# Altium schematic sheet Power.SchDoc(Power)
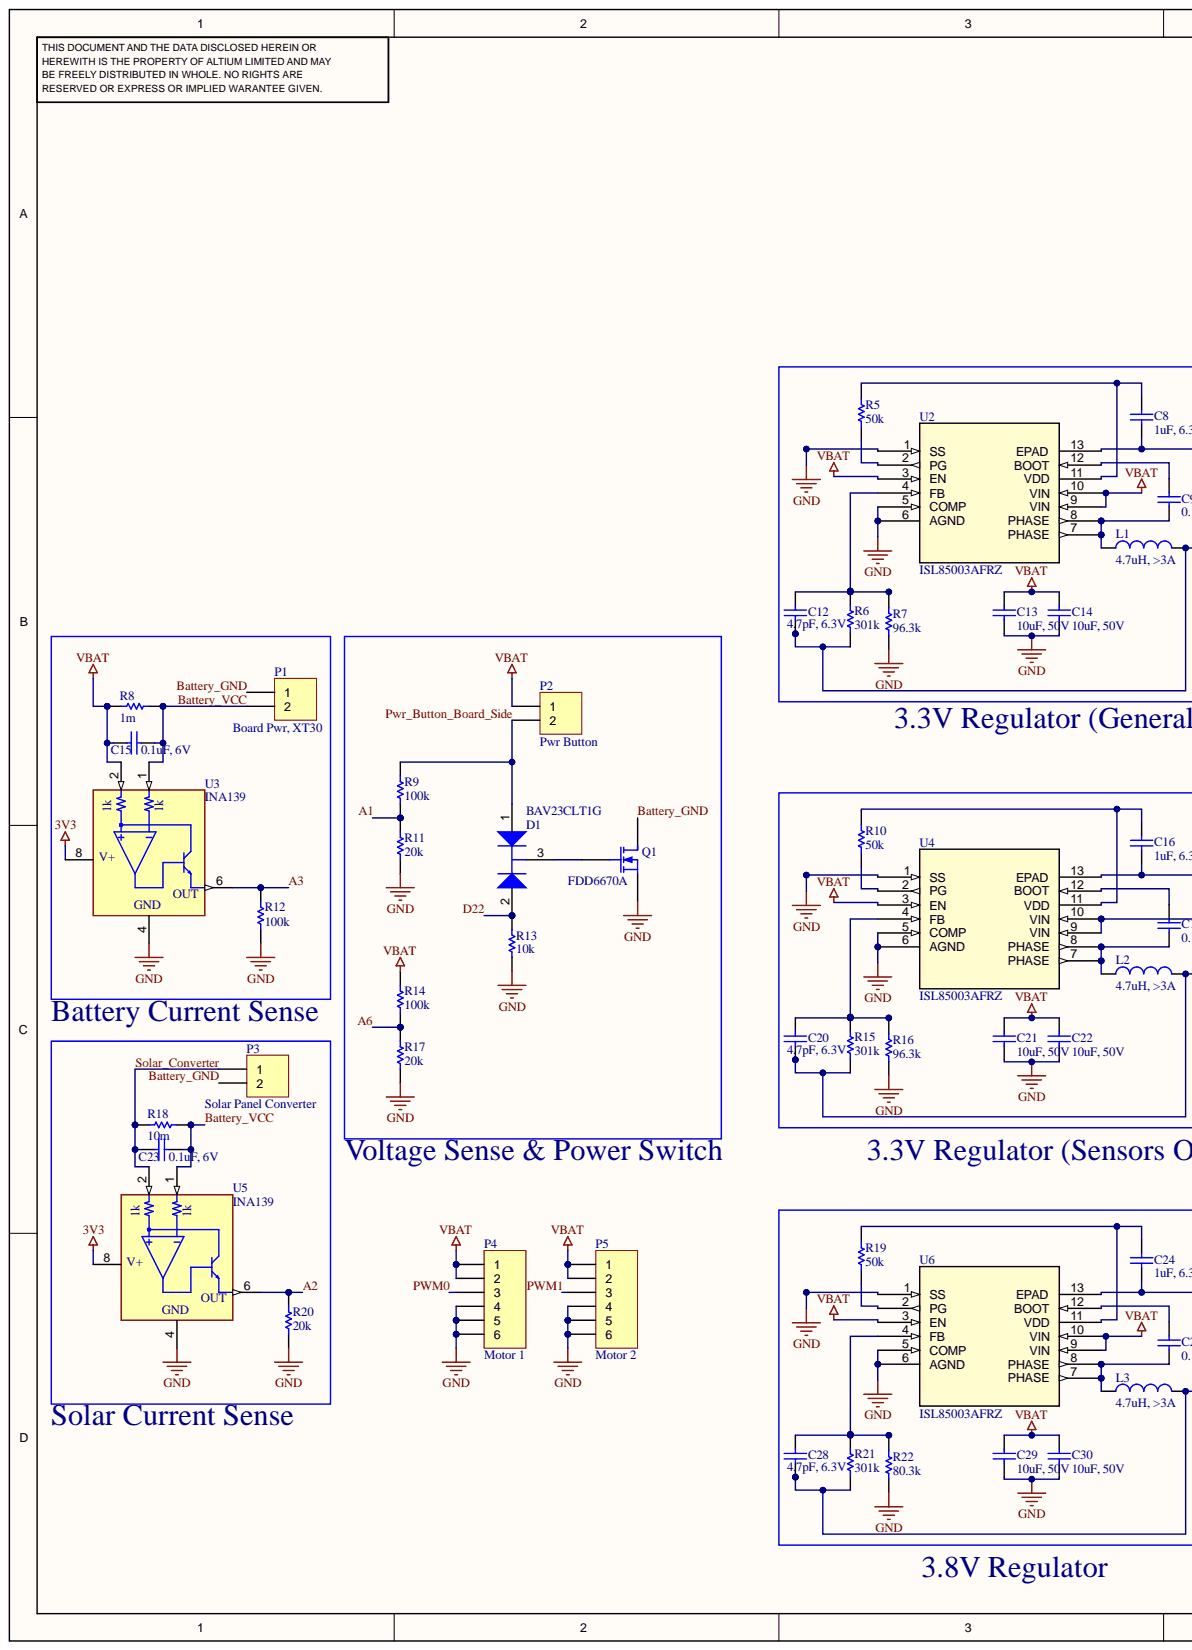
[diagram p0: Power.SchDoc, left]
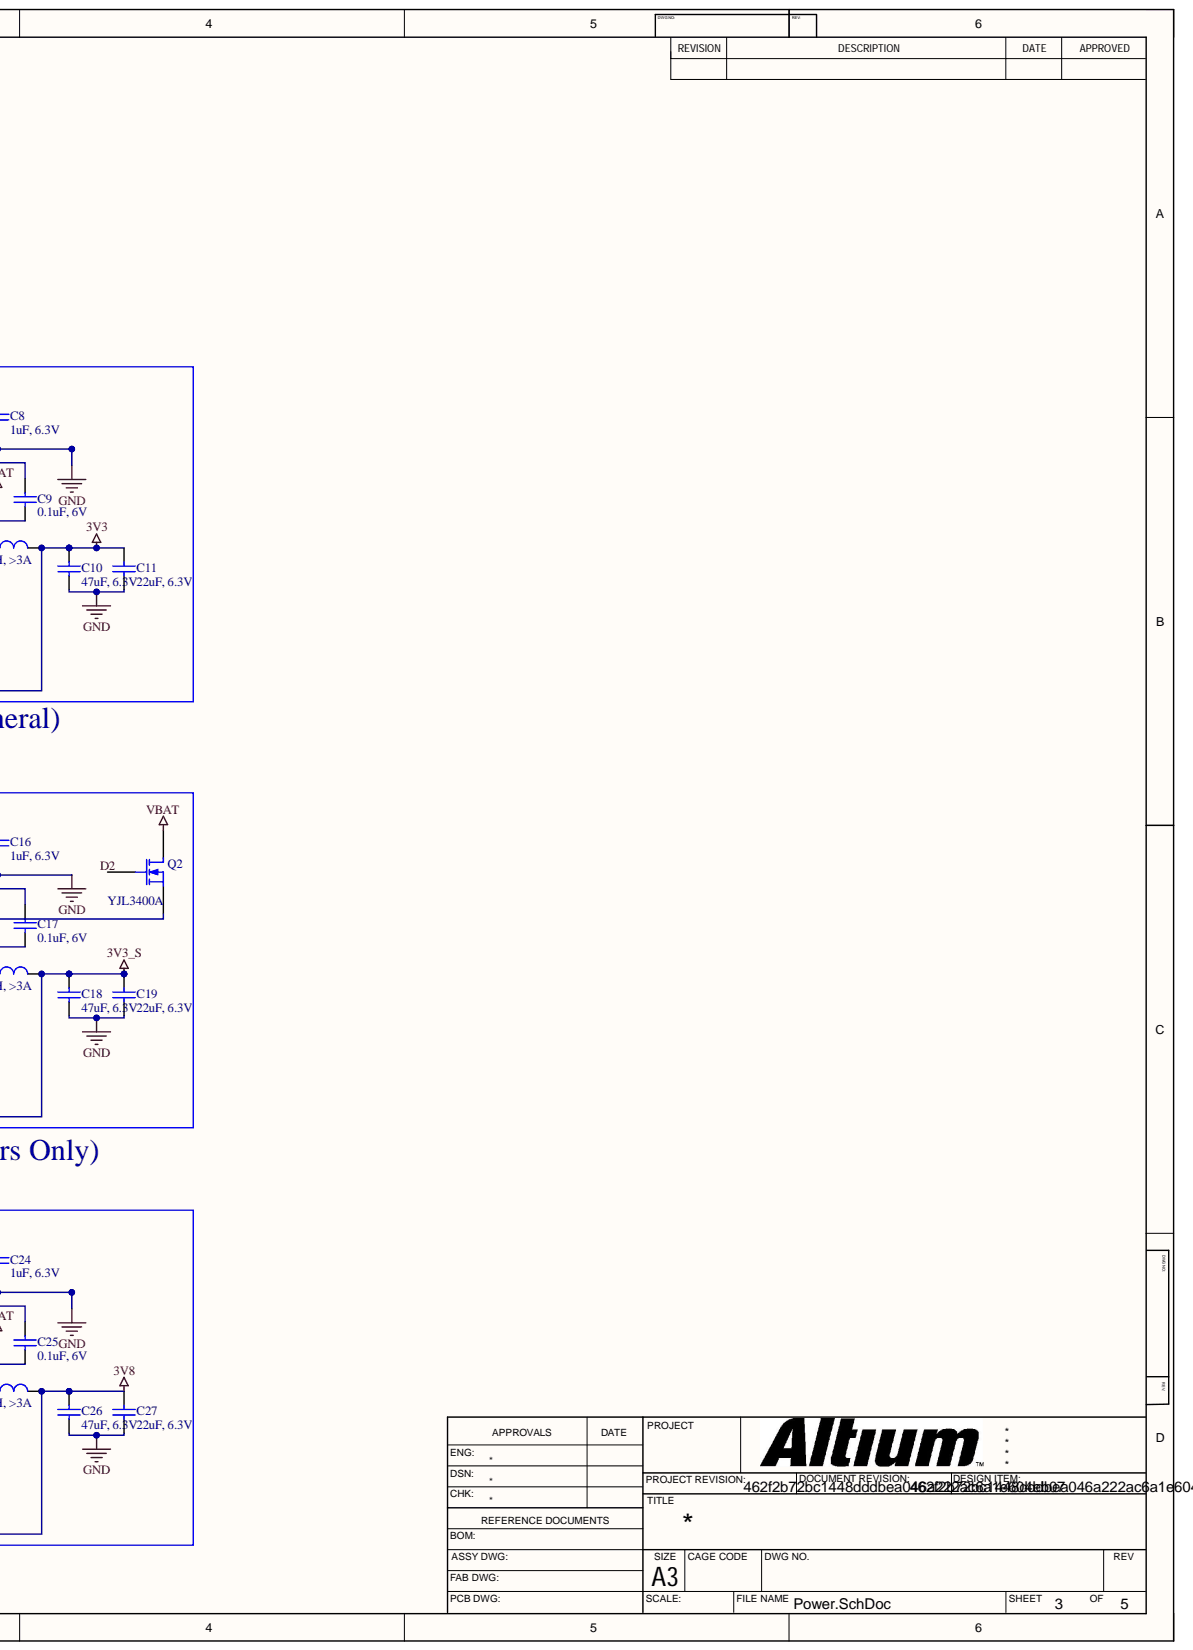
[diagram p1: Power.SchDoc, right]

## Parts
C8  value 1uF, 6.3V  [ANTC1608X90N]  (hidden comment: Capacitor)
C9  value 0.1uF, 6V  [ANTC1608X90N]  (hidden comment: Capacitor)
C10  value 47uF, 6.3V  [ANTC1608X90N]  (hidden comment: Capacitor)
C11  value 22uF, 6.3V  [ANTC1608X90N]  (hidden comment: Capacitor)
C12  value 4.7pF, 6.3V  [ANTC1608X90N]  (hidden comment: Capacitor)
C13  value 10uF, 50V  [CAPC2012X145N]  (hidden comment: Capacitor)
C14  value 10uF, 50V  [CAPC2012X145N]  (hidden comment: Capacitor)
C15  value 0.1uF, 6V  [ANTC1608X90N]  (hidden comment: Capacitor)
C16  value 1uF, 6.3V  [ANTC1608X90N]  (hidden comment: Capacitor)
C17  value 0.1uF, 6V  [ANTC1608X90N]  (hidden comment: Capacitor)
C18  value 47uF, 6.3V  [ANTC1608X90N]  (hidden comment: Capacitor)
C19  value 22uF, 6.3V  [ANTC1608X90N]  (hidden comment: Capacitor)
C20  value 4.7pF, 6.3V  [ANTC1608X90N]  (hidden comment: Capacitor)
C21  value 10uF, 50V  [CAPC2012X145N]  (hidden comment: Capacitor)
C22  value 10uF, 50V  [CAPC2012X145N]  (hidden comment: Capacitor)
C23  value 0.1uF, 6V  [ANTC1608X90N]  (hidden comment: Capacitor)
C24  value 1uF, 6.3V  [ANTC1608X90N]  (hidden comment: Capacitor)
C25  value 0.1uF, 6V  [ANTC1608X90N]  (hidden comment: Capacitor)
C26  value 47uF, 6.3V  [ANTC1608X90N]  (hidden comment: Capacitor)
C27  value 22uF, 6.3V  [ANTC1608X90N]  (hidden comment: Capacitor)
C28  value 4.7pF, 6.3V  [ANTC1608X90N]  (hidden comment: Capacitor)
C29  value 10uF, 50V  [CAPC2012X145N]  (hidden comment: Capacitor)
C30  value 10uF, 50V  [CAPC2012X145N]  (hidden comment: Capacitor)
D1  BAV23CLT1G  [ONSC-SOT-23-3-318-08_V]
L1  value 4.7uH, >3A  [IND_NR6028T4R7M]  (hidden comment: Inductor)
L2  value 4.7uH, >3A  [IND_NR6028T4R7M]  (hidden comment: Inductor)
L3  value 4.7uH, >3A  [IND_NR6028T4R7M]  (hidden comment: Inductor)
P1  Board Pwr, XT30  [XT30_Horizontal]
P2  Pwr Button  [TE_6-440054-2]
P3  Solar Panel Converter  [XT30_Horizontal]
P4  Motor 1  [TE_1586039-6]
P5  Motor 2  [TE_1586039-6]
Q1  FDD6670A  [DPAK]
Q2  YJL3400A  [SOT23-3]
R5  value 50k  [ANTC1608X90N]  (hidden comment: Resistor)
R6  value 301k  [ANTC1608X90N]  (hidden comment: Resistor)
R7  value 96.3k  [ANTC1608X90N]  (hidden comment: Resistor)
R8  value 1m  [RESC6331X70N]  (hidden comment: Resistor)
R9  value 100k  [ANTC1608X90N]  (hidden comment: Resistor)
R10  value 50k  [ANTC1608X90N]  (hidden comment: Resistor)
R11  value 20k  [ANTC1608X90N]  (hidden comment: Resistor)
R12  value 100k  [ANTC1608X90N]  (hidden comment: Resistor)
R13  value 10k  [ANTC1608X90N]  (hidden comment: Resistor)
R14  value 100k  [ANTC1608X90N]  (hidden comment: Resistor)
R15  value 301k  [ANTC1608X90N]  (hidden comment: Resistor)
R16  value 96.3k  [ANTC1608X90N]  (hidden comment: Resistor)
R17  value 20k  [ANTC1608X90N]  (hidden comment: Resistor)
R18  value 10m  [RESC6331X70N]  (hidden comment: Resistor)
R19  value 50k  [ANTC1608X90N]  (hidden comment: Resistor)
R20  value 20k  [ANTC1608X90N]  (hidden comment: Resistor)
R21  value 301k  [ANTC1608X90N]  (hidden comment: Resistor)
R22  value 80.3k  [ANTC1608X90N]  (hidden comment: Resistor)
U2  ISL85003AFRZ  [SON50P300X300X100-13N(270X200)]
U3  INA139  [INA139-Q1]
U4  ISL85003AFRZ  [SON50P300X300X100-13N(270X200)]
U5  INA139  [INA139-Q1]
U6  ISL85003AFRZ  [SON50P300X300X100-13N(270X200)]

## Nets
3V3: C10.2 C11.2 C12.1 L1.2 R6.1 U3.8(V+) U5.8(V+)
3V3_S: C18.2 C19.2 C20.1 L2.2 R15.1
3V8: C26.2 C27.2 C28.1 L3.2 R21.1
GND: C8.1 C10.1 C11.1 C13.1 C14.1 C16.1 C18.1 C19.1 C21.1 C22.1 C24.1 C26.1 C27.1 C29.1 C30.1 P4.4 P4.5 P4.6 P5.4 P5.5 P5.6 Q1.3 R7.1 R11.1 R12.1 R13.1 R16.1 R17.1 R20.1 R22.1 U2.1(SS) U2.5(COMP) U2.6(AGND) U2.13(EPAD) U3.4 U4.1(SS) U4.5(COMP) U4.6(AGND) U4.13(EPAD) U5.4 U6.1(SS) U6.5(COMP) U6.6(AGND) U6.13(EPAD)
VBAT: C13.2 C14.2 C15.2 C21.2 C22.2 C29.2 C30.2 P2.1 P4.1 P4.2 P5.1 P5.2 Q2.1 R8.2 R14.2 U2.3(EN) U2.9(VIN) U2.10(VIN) U3.2 U4.3(EN) U6.3(EN) U6.9(VIN) U6.10(VIN)
A1: R9.1 R11.2
A2: R20.2 U5.6
A3: R12.2 U3.6
A6: R14.1 R17.2
Battery_GND: P1.1 P3.2 Q1.1
Battery_VCC: C15.1 C23.1 P1.2 R8.1 R18.2 U3.1 U5.1
D2: Q2.2
D22: D1.2 R13.2
PWM0: P4.3
PWM1: P5.3
Pwr_Button_Board_Side: D1.1 P2.2 R9.2
Solar_Converter: C23.2 P3.1 R18.1 U5.2
NetC8_2: C8.2 R5.2 U2.11(VDD)
NetC9_1: C9.1 L1.1 U2.7(PHASE) U2.8(PHASE)
NetC9_2: C9.2 U2.12(BOOT)
NetC12_2: C12.2 R6.2 R7.2 U2.4(FB)
NetC16_2: C16.2 R10.2 U4.11(VDD)
NetC17_1: C17.1 L2.1 U4.7(PHASE) U4.8(PHASE)
NetC17_2: C17.2 U4.12(BOOT)
NetC20_2: C20.2 R15.2 R16.2 U4.4(FB)
NetC24_2: C24.2 R19.2 U6.11(VDD)
NetC25_1: C25.1 L3.1 U6.7(PHASE) U6.8(PHASE)
NetC25_2: C25.2 U6.12(BOOT)
NetC28_2: C28.2 R21.2 R22.2 U6.4(FB)
NetD1_3: D1.3 Q1.2
NetQ2_3: Q2.3 U4.9(VIN) U4.10(VIN)
NetR5_1: R5.1 U2.2(PG)
NetR10_1: R10.1 U4.2(PG)
NetR19_1: R19.1 U6.2(PG)

## Library data of the parts
C8 C9 C11 C12 C14 C16 C17 C19 C20 C22 C24 C25 C27 C28 C30 = Capacitor: SimulationGenericComponent: True; Component Kind: Standard; Description: Capacitor; Library Name: Simulation Generic Components.IntLib; Simulation: C_Capacitor_Default
C10 C18 C26 = Capacitor: SimulationGenericComponent: True; Value: 47uF, 6.3V; Component Kind: Standard; Description: Capacitor; Library Name: Simulation Generic Components.IntLib; Simulation: C_Capacitor_Default
C13 C21 C29 = Capacitor: SimulationGenericComponent: True; Value: 10uF, 50V; Component Kind: Standard; Description: Capacitor; Library Name: Simulation Generic Components.IntLib; Simulation: C_Capacitor_Default
C15 C23 = Capacitor: SimulationGenericComponent: True; Value: 0.1uF, 6V; Component Kind: Standard; Description: Capacitor; Library Name: Simulation Generic Components.IntLib; Simulation: C_Capacitor_Default
D1 = CMP-1054-00102-1: Dual High Voltage Common Cathode Switching Diodes, 3-Pin SOT-23, Pb-Free, Tape and Reel; Manufacturer: On Semiconductor; IF Max (A): 0.4; trr Max (ns): 150; Component Kind: Standard; ComponentLink1Description: Manufacturer URL; ComponentLink1URL: http://www.onsemi.com/; ComponentLink2Description: Datasheet; ComponentLink2URL: http://www.onsemi.com/pub_link/Collateral/BAV23CLT1-D.PDF; ComponentLink3Description: Package Specification; ComponentLink3URL: http://www.onsemi.cn/pub_link/Collateral/318-08.PDF; Configuration: Common Cathode; DatasheetVersion: Rev. 2; Library Name: Altium Content Vault; Mounting Technology: Surface Mount; PackageDescription: 3-Pin Small Outline Transistor, Body 2.92 x 1.3 mm, Pitch 0.95 mm; PackageReference: SOT-23-3-318-08; PackageVersion: Rev. AP, 11/2009; Packing: Tape and Reel; PartNumber: BAV23CLT1G; RoHS: TRUE
L1-L3 = Inductor: SimulationGenericComponent: True; Component Kind: Standard; Description: Inductor; Library Name: Simulation Generic Components.IntLib; Simulation: L_Inductor_Default
P1-P3 = Header 2: Header, 2-Pin; Component Kind: Standard; LatestRevisionDate: 17-Jul-2002; LatestRevisionNote: Re-released for DXP Platform.; Library Name: Miscellaneous Connectors.IntLib; Signal Integrity: Connector; Publisher: Altium Limited
P4 P5 = Header 6: Header, 6-Pin; Component Kind: Standard; LatestRevisionDate: 17-Jul-2002; LatestRevisionNote: Re-released for DXP Platform.; Library Name: Miscellaneous Connectors.IntLib; Signal Integrity: Connector; Publisher: Altium Limited
Q1 Q2 = MOSFET-N: N-Channel MOSFET; Component Kind: Standard; LatestRevisionDate: 17-Jul-2002; LatestRevisionNote: Re-released for DXP Platform.; Library Name: Miscellaneous Devices.IntLib; PackageDescription: TO, Flat Index; 3 In-Line, Axial Leads; Body Dia. 4.6mm; Lead Dia. 0.5 mm (max); PackageReference: E3; PackageVersion: 1995/1996; Published: 8-Jun-2000; Simulation: NMOS; Publisher: Altium Limited
R5-R22 = Resistor: SimulationGenericComponent: True; TC1: 0; Component Kind: Standard; Description: Resistor; Library Name: Simulation Generic Components.IntLib; Simulation: R_Resistor_Default; TC2: 0
U2 U4 U6 = ISL85003AFRZ: No Description Available; Mfr_Name: Renesas Electronics; Component Kind: Standard; Digi-Key_Part_Number_1: ISL85003AFRZ-ND; Library Name: ISL85003AFRZ.SchLib; Manufacturer_Part_Number: ISL85003AFRZ; RefDes: RefDes; Type: DEV; Copyright: Copyright (C) 2022 Ultra Librarian. All rights reserved.
U3 U5 = INA139: Current Monitor Regulator High-Side SOT-23-5; MF: Texas Instruments; Availability: Unavailable; Component Kind: Standard; Library Name: INA139.IntLib; MP: INA139; Package: SOT-23-5 Texas Instruments; Price: None; SnapEDA_Link: https://www.snapeda.com/parts/INA139/Texas%20Instruments/view-part/560986/?ref=snap
